# Altium schematic sheet METR4810_Schematic.SchDoc
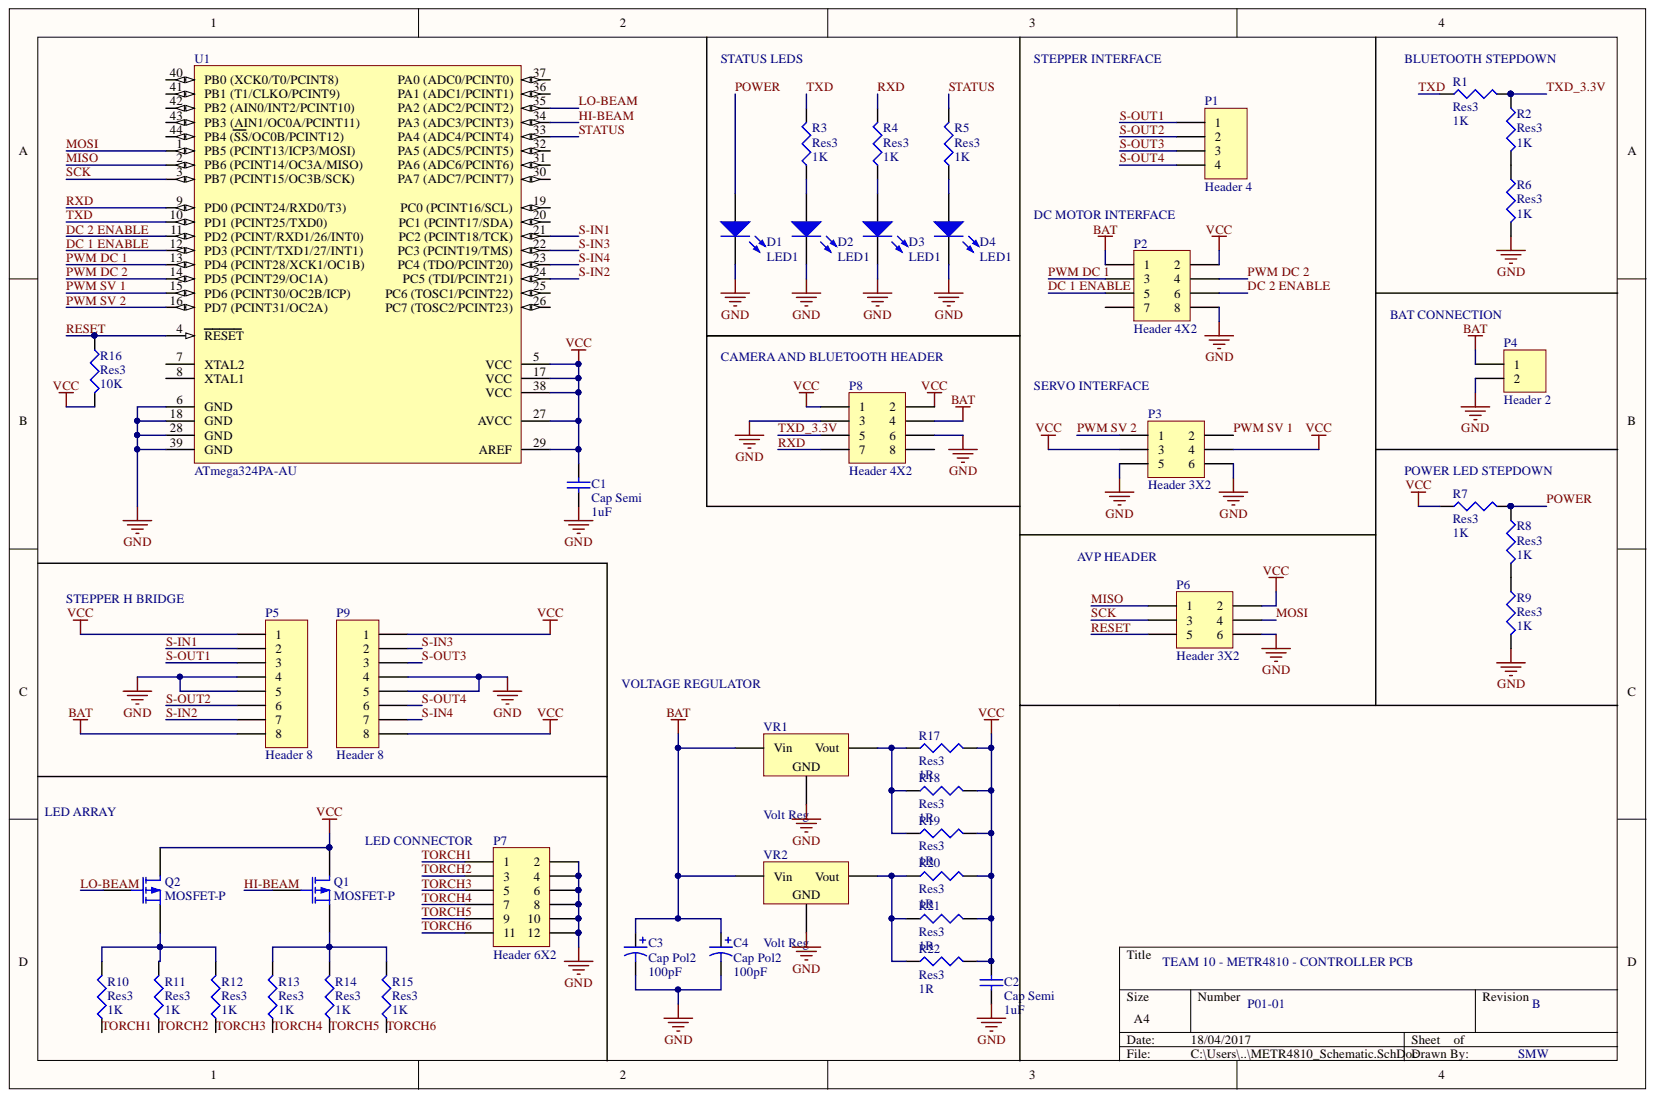
[diagram p0: METR4810_Schematic.SchDoc]

## Parts
C1  Cap Semi  value 1uF  [C1206]
C2  Cap Semi  value 1uF  [C1206]
C3  Cap Pol2  value 100pF  [CAPAE9983X105M]
C4  Cap Pol2  value 100pF  [CAPAE9983X105M]
D1  LED1  [L0603]
D2  LED1  [L0603]
D3  LED1  [L0603]
D4  LED1  [L0603]
P1  Header 4  [HDR1X4]
P2  Header 4X2  [HDR2X4]
P3  Header 3X2  [HDR2X3]
P4  Header 2  [HDR1X2]
P5  Header 8  [HDR1X8]
P6  Header 3X2  [HDR2X3]
P7  Header 6X2  [HDR2X6]
P8  Header 4X2  [HDR2X4]
P9  Header 8  [HDR1X8]
Q1  MOSFET-P  [SOT23-3]
Q2  MOSFET-P  [SOT23-3]
R1  Res3  value 1K  [J1-0603]
R2  Res3  value 1K  [J1-0603]
R3  Res3  value 1K  [J1-0603]
R4  Res3  value 1K  [J1-0603]
R5  Res3  value 1K  [J1-0603]
R6  Res3  value 1K  [J1-0603]
R7  Res3  value 1K  [J1-0603]
R8  Res3  value 1K  [J1-0603]
R9  Res3  value 1K  [J1-0603]
R10  Res3  value 1K  [J1-0603]
R11  Res3  value 1K  [J1-0603]
R12  Res3  value 1K  [J1-0603]
R13  Res3  value 1K  [J1-0603]
R14  Res3  value 1K  [J1-0603]
R15  Res3  value 1K  [J1-0603]
R16  Res3  value 10K  [J1-0603]
R17  Res3  [J1-0603]
R18  Res3  value 1R  [J1-0603]
R19  Res3  value 1R  [J1-0603]
R20  Res3  value 1R  [J1-0603]
R21  Res3  value 1R  [J1-0603]
R22  Res3  value 1R  [J1-0603]
U1  ATmega324PA-AU  [44A_N]
VR1  Volt Reg  [D2PAK_N]
VR2  Volt Reg  [D2PAK_N]

## Nets
BAT: C3.1 C4.1 P2.1 P4.1 P5.8 P8.4 VR1.1 VR2.1
GND: C1.1 C2.1 C3.2 C4.2 D1.2 D2.2 D3.2 D4.2 P2.8 P3.5 P3.6 P4.2 P5.4 P5.5 P6.6 P7.2 P7.4 P7.6 P7.8 P7.10 P7.12 P8.3 P8.6 P9.4 P9.5 R6.2 R9.2 U1.6(GND) U1.18(GND) U1.28(GND) U1.39(GND) VR1.2 VR2.2
VCC: C1.2 C2.2 P2.2 P3.3 P3.4 P5.1 P6.2 P8.1 P8.2 P9.1 P9.8 Q1.1 Q2.1 R7.1 R16.2 R17.2 R18.2 R19.2 R20.2 R21.2 R22.2 U1.5(VCC) U1.17(VCC) U1.27(AVCC) U1.29(AREF) U1.38(VCC)
DC 1 ENABLE: P2.5 U1.12(PD3_(PCINT/TXD1/27/INT1))
DC 2 ENABLE: P2.6 U1.11(PD2_(PCINT/RXD1/26/INT0))
HI-BEAM: Q1.2 U1.34(PA3_(ADC3/PCINT3))
LO-BEAM: Q2.2 U1.35(PA2_(ADC2/PCINT2))
MISO: P6.1 U1.2(PB6_(PCINT14/OC3A/MISO))
MOSI: P6.4 U1.1(PB5_(PCINT13/ICP3/MOSI))
POWER: D1.1 R7.2 R8.1
PWM DC 1: P2.3 U1.13(PD4_(PCINT28/XCK1/OC1B))
PWM DC 2: P2.4 U1.14(PD5_(PCINT29/OC1A))
PWM SV 1: P3.2 U1.15(PD6_(PCINT30/OC2B/ICP))
PWM SV 2: P3.1 U1.16(PD7_(PCINT31/OC2A))
RESET: P6.5 R16.1 U1.4(RESET)
RXD: P8.7 R4.2 U1.9(PD0_(PCINT24/RXD0/T3))
S-IN1: P5.2 U1.21(PC2_(PCINT18/TCK))
S-IN2: P5.7 U1.24(PC5_(TDI/PCINT21))
S-IN3: P9.2 U1.22(PC3_(PCINT19/TMS))
S-IN4: P9.7 U1.23(PC4_(TDO/PCINT20))
S-OUT1: P1.1 P5.3
S-OUT2: P1.2 P5.6
S-OUT3: P1.3 P9.3
S-OUT4: P1.4 P9.6
SCK: P6.3 U1.3(PB7_(PCINT15/OC3B/SCK))
STATUS: R5.2 U1.33(PA4_(ADC4/PCINT4))
TORCH1: P7.1 R10.1
TORCH2: P7.3 R11.1
TORCH3: P7.5 R12.1
TORCH4: P7.7 R13.1
TORCH5: P7.9 R14.1
TORCH6: P7.11 R15.1
TXD: R1.1 R3.2 U1.10(PD1_(PCINT25/TXD0))
TXD_3.3V: P8.5 R1.2 R2.1
NetD2_1: D2.1 R3.1
NetD3_1: D3.1 R4.1
NetD4_1: D4.1 R5.1
NetQ1_3: Q1.3 R13.2 R14.2 R15.2
NetQ2_3: Q2.3 R10.2 R11.2 R12.2
NetR2_2: R2.2 R6.1
NetR8_2: R8.2 R9.1
NetR17_1: R17.1 R18.1 R19.1 VR1.3
NetR20_1: R20.1 R21.1 R22.1 VR2.3

Not connected: P2 7; P8 8; U1 7(XTAL2) 8(XTAL1) 19(PC0_(PCINT16/SCL)) 20(PC1_(PCINT17/SDA)) 25(PC6_(TOSC1/PCINT22)) 26(PC7_(TOSC2/PCINT23)) 30(PA7_(ADC7/PCINT7)) 31(PA6_(ADC6/PCINT6)) 32(PA5_(ADC5/PCINT5)) 36(PA1_(ADC1/PCINT1)) 37(PA0_(ADC0/PCINT0)) 40(PB0_(XCK0/T0/PCINT8)) 41(PB1_(T1/CLKO/PCINT9)) 42(PB2_(AIN0/INT2/PCINT10)) 43(PB3_(AIN1/OC0A/PCINT11)) 44(PB4_(SS/OC0B/PCINT12))

## Library data of the parts
C1 C2 = Cap Semi: Capacitor (Semiconductor SIM Model); Component Kind: Standard; LatestRevisionDate: 29-May-2009; LatestRevisionNote: IPC-7351 Footprint Added.; Library Name: Miscellaneous Devices.IntLib; PackageDescription: Chip Capacitor; PackageReference: C1206; Published: 8-Jun-2000; Signal Integrity: Cap Semi; Simulation: CAP; Publisher: Altium Limited
C3 C4 = Cap Pol2: Polarized Capacitor (Axial); Component Kind: Standard; LatestRevisionDate: 17-Jul-2002; LatestRevisionNote: Re-released for DXP Platform.; Library Name: Miscellaneous Devices.IntLib; PackageDescription: Polarized Capacitor; 2 Leads; PackageReference: POLAR0.8; Published: 8-Jun-2000; Signal Integrity: Cap Pol; Simulation: CAP; Publisher: Altium Limited
D1-D4 = LED1: Typical RED GaAs LED; Component Kind: Standard; LatestRevisionDate: 08-Jul-2005; LatestRevisionNote: Mech Layer 1 removed.; Library Name: Miscellaneous Devices.IntLib; PackageDescription: LED; 2 Leads; PackageReference: LED-1; Published: 8-Jun-2000; Simulation: LED1; Publisher: Altium Limited
P1 = Header 4: Header, 4-Pin; Component Kind: Standard; LatestRevisionDate: 17-Jul-2002; LatestRevisionNote: Re-released for DXP Platform.; Library Name: Miscellaneous Connectors.IntLib; Signal Integrity: Connector; Publisher: Altium Limited
P2 P8 = Header 4X2: Header, 4-Pin, Dual row; Component Kind: Standard; LatestRevisionDate: 17-Jul-2002; LatestRevisionNote: Re-released for DXP Platform.; Library Name: Miscellaneous Connectors.IntLib; Signal Integrity: Connector; Publisher: Altium Limited
P3 P6 = Header 3X2: Header, 3-Pin, Dual row; Component Kind: Standard; LatestRevisionDate: 17-Jul-2002; LatestRevisionNote: Re-released for DXP Platform.; Library Name: Miscellaneous Connectors.IntLib; Signal Integrity: Connector; Publisher: Altium Limited
P4 = Header 2: Header, 2-Pin; Component Kind: Standard; LatestRevisionDate: 17-Jul-2002; LatestRevisionNote: Re-released for DXP Platform.; Library Name: Miscellaneous Connectors.IntLib; Signal Integrity: Connector; Publisher: Altium Limited
P5 P9 = Header 8: Header, 8-Pin; Component Kind: Standard; LatestRevisionDate: 17-Jul-2002; LatestRevisionNote: Re-released for DXP Platform.; Library Name: Miscellaneous Connectors.IntLib; Signal Integrity: Connector; Publisher: Altium Limited
P7 = Header 6X2: Header, 6-Pin, Dual row; Component Kind: Standard; LatestRevisionDate: 17-Jul-2002; LatestRevisionNote: Re-released for DXP Platform.; Library Name: Miscellaneous Connectors.IntLib; Signal Integrity: Connector; Publisher: Altium Limited
Q1 Q2 = MOSFET-P: P-Channel MOSFET; Component Kind: Standard; LatestRevisionDate: 17-Jul-2002; LatestRevisionNote: Re-released for DXP Platform.; Library Name: Miscellaneous Devices.IntLib; PackageDescription: TO, Flat Index; 3 In-Line, Axial Leads; Body Dia. 4.6mm; Lead Dia. 0.5 mm (max); PackageReference: E3; PackageVersion: 1995/1996; Published: 8-Jun-2000; Simulation: PMOS; Publisher: Altium Limited
R1-R16 R18-R22 = Res3: Resistor; Component Kind: Standard; LatestRevisionDate: 29-May-2009; LatestRevisionNote: IPC-7351 Footprint Added.; Library Name: Miscellaneous Devices.IntLib; PackageDescription: Chip Resistor; PackageReference: J1-0603; Published: 8-Jun-2000; Signal Integrity: Res; Simulation: RESISTOR; Publisher: Altium Limited
R17 = Res3: Resistor; Value: 1R; Component Kind: Standard; LatestRevisionDate: 29-May-2009; LatestRevisionNote: IPC-7351 Footprint Added.; Library Name: Miscellaneous Devices.IntLib; PackageDescription: Chip Resistor; PackageReference: J1-0603; Published: 8-Jun-2000; Signal Integrity: Res; Simulation: RESISTOR; Publisher: Altium Limited
U1 = ATmega324PA-AU: 8-bit AVR Microcontroller, 32KB Flash, 1KB EEPROM, 2KB SRAM, 44-pin TQFP, Industrial Grade (-40°C to 85°C); Code_JEDEC: MS-026-ACB; Component Kind: Standard; ComponentLink1Description: Manufacturer URL; ComponentLink1URL: http://www.atmel.com/; ComponentLink2Description: ATmega164A/164PA/324A/324PA/644A/644PA/1284/1284P Datasheet; ComponentLink2URL: http://www.atmel.com/dyn/resources/prod_documents/doc8272.pdf; ComponentLink3Description: ATmega164A/164PA/324A/324PA/644A/644PA/1284/1284P Summary; ComponentLink3URL: http://www.atmel.com/dyn/resources/prod_documents/8272S.pdf; DatasheetVersion: revC, Jun-2011; Library Name: Atmel Microcontroller megaAVR.IntLib; PackageDescription: 44-Pin Plastic Thin Profile Quad Flat Pack, 10 x 10 x 1.0 mm Body, 0.8 mm Pitch (TQFP); PackageReference: 44A; PackageVersion: revC, Oct-2010
VR1 VR2 = Volt Reg: Voltage Regulator; Component Kind: Standard; LatestRevisionDate: 29-May-2009; LatestRevisionNote: IPC-7351 Footprint Added.; Library Name: Miscellaneous Devices.IntLib; PackageDescription: 3-Pin Transistor Outline Package 2.54 mm Pitch, 15.1 mm Lead Span; PackageReference: D2PAK; Published: 24-Mar-1999; Publisher: Altium Limited
